# Altium schematic sheet 02_FPGA_Clock.SchDoc
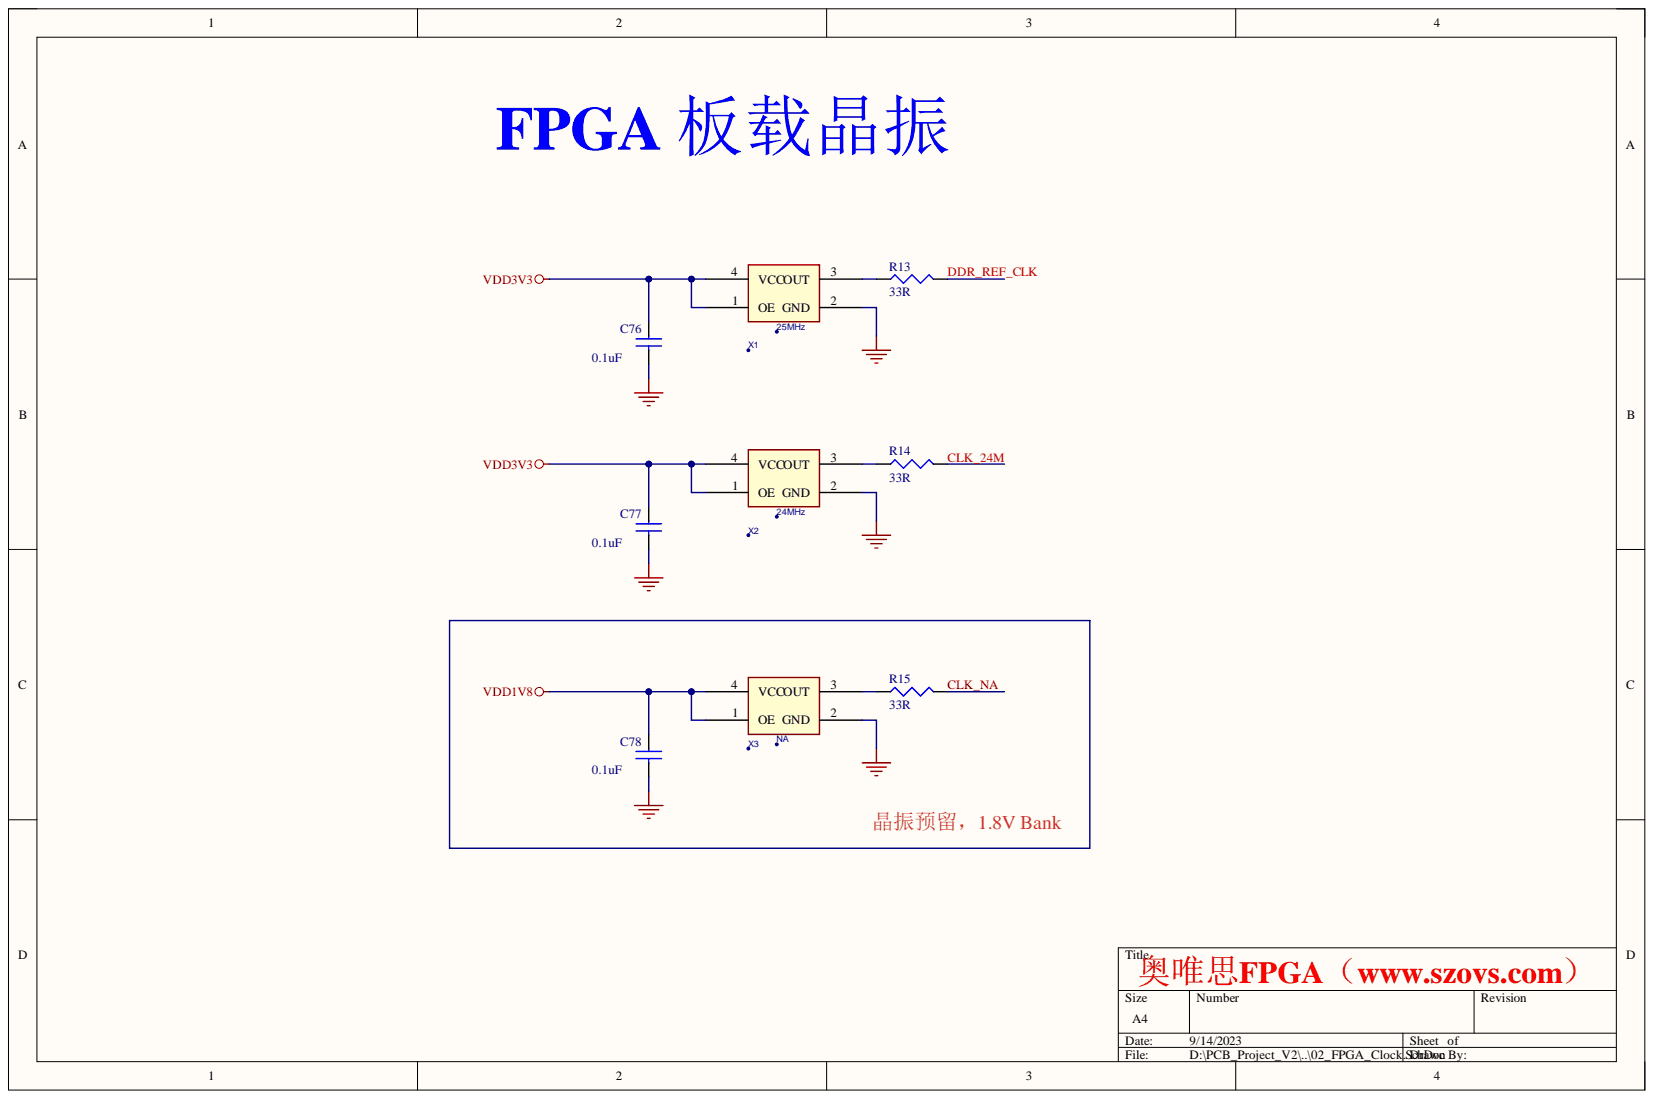
[diagram p0: 02_FPGA_Clock.SchDoc]

## Parts
C76  0.1uF  [R0402]
C77  0.1uF  [R0402]
C78  0.1uF  [R0402]
R13  33R  [R0402]
R14  33R  [R0402]
R15  33R  [R0402]
X1  Pin Names Rotate: 25MHz  [XTAL_3225]  (hidden comment: 50MHZ-OSC)
X2  Pin Names Rotate: 24MHz  [XTAL_3225]  (hidden comment: 27MHZ-OSC)
X3  Pin Names Rotate: NA  [XTAL_3225]  (hidden comment: 12MHZ-OSC)

## Nets
GND: C76.2 C77.2 C78.2 X1.2(GND) X2.2(GND) X3.2(GND)
VDD1V8: C78.1 X3.1(OE) X3.4(VCC)
VDD3V3: C76.1 C77.1 X1.1(OE) X1.4(VCC) X2.1(OE) X2.4(VCC)
CLK_24M: R14.2
CLK_NA: R15.2
DDR_REF_CLK: R13.2
NetR13_1: R13.1 X1.3(OUT)
NetR14_1: R14.1 X2.3(OUT)
NetR15_1: R15.1 X3.3(OUT)

## Library data of the parts
C76-C78 = C0402: Component Kind: Standard; Library Name: 162_Library.SchLib
R13-R15 = R: Component Kind: Standard; Library Name: 162_Library.SchLib
X1-X3 = CRYSTAL_0: Component Kind: Standard
